# Altium schematic sheet Sheet2.SchDoc(Sheet2)
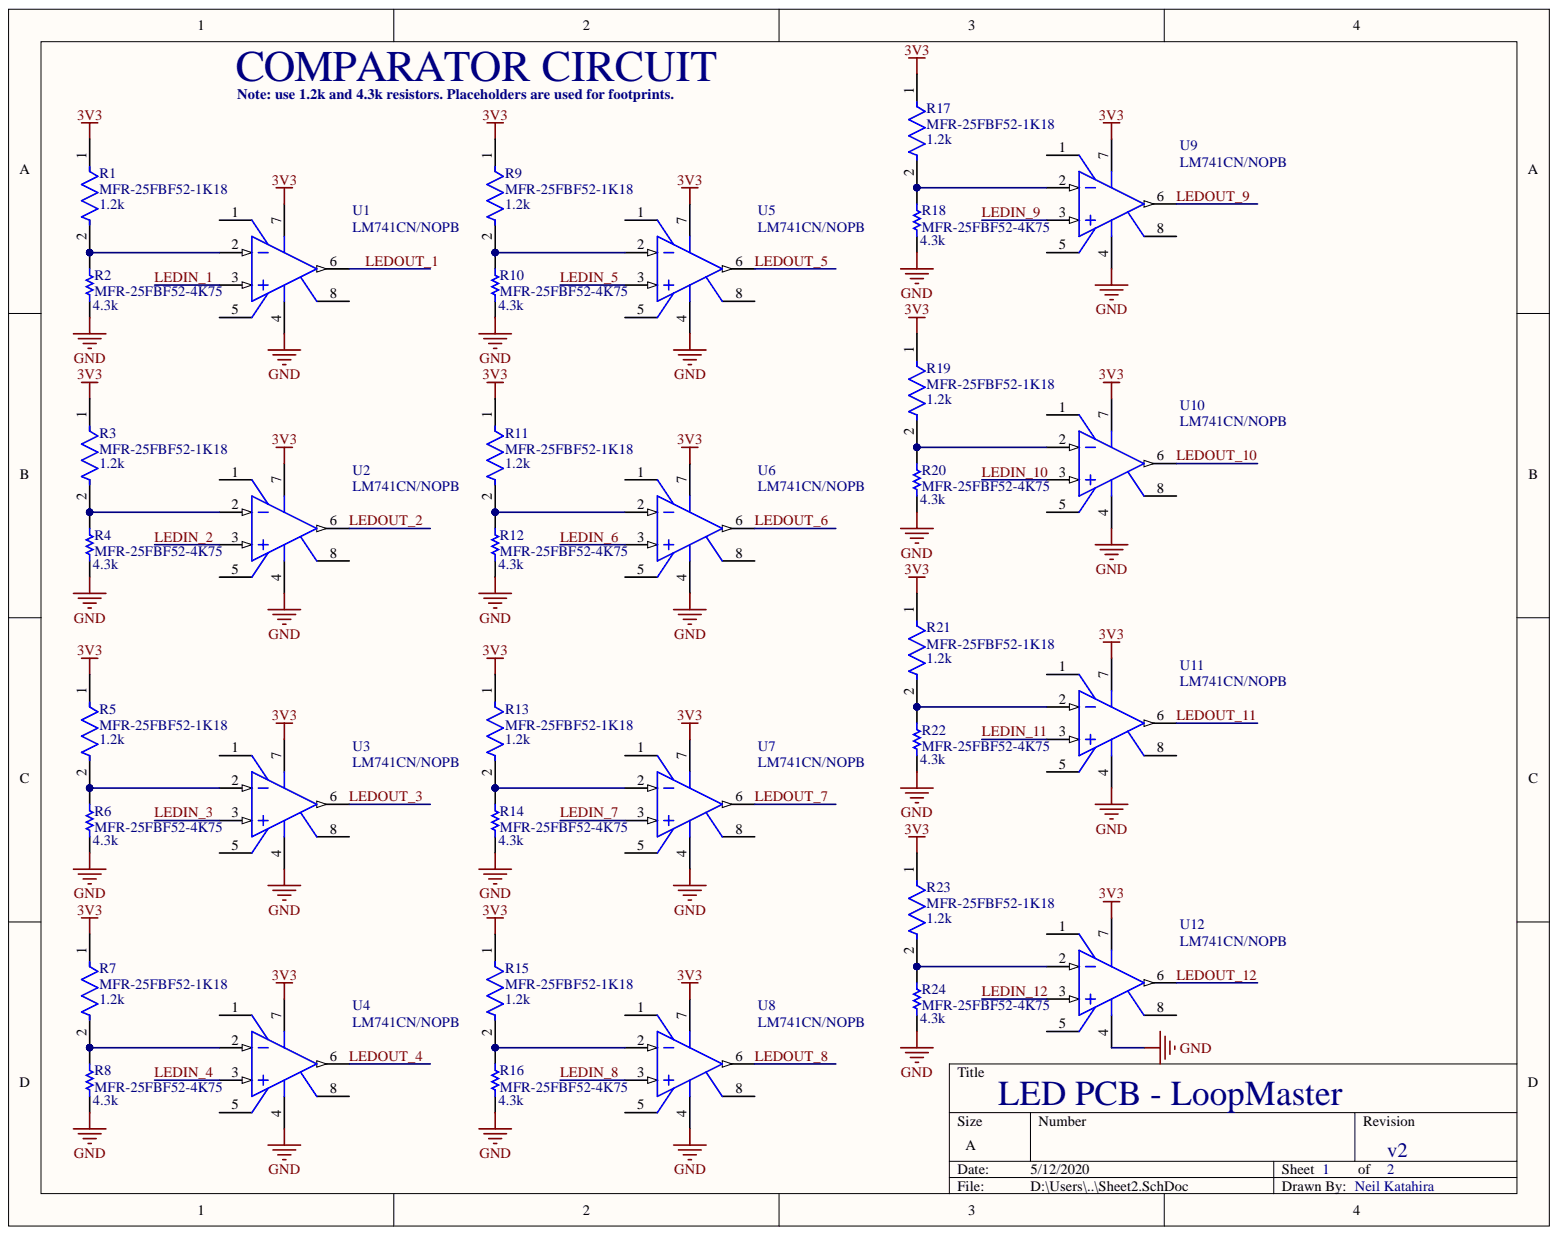
[diagram p0: Sheet2.SchDoc]

## Parts
R1  MFR-25FBF52-1K18  [FP-MFR-25-MFG]
R2  MFR-25FBF52-4K75  [RESA60-630X240]
R3  MFR-25FBF52-1K18  [FP-MFR-25-MFG]
R4  MFR-25FBF52-4K75  [RESA60-630X240]
R5  MFR-25FBF52-1K18  [FP-MFR-25-MFG]
R6  MFR-25FBF52-4K75  [RESA60-630X240]
R7  MFR-25FBF52-1K18  [FP-MFR-25-MFG]
R8  MFR-25FBF52-4K75  [RESA60-630X240]
R9  MFR-25FBF52-1K18  [FP-MFR-25-MFG]
R10  MFR-25FBF52-4K75  [RESA60-630X240]
R11  MFR-25FBF52-1K18  [FP-MFR-25-MFG]
R12  MFR-25FBF52-4K75  [RESA60-630X240]
R13  MFR-25FBF52-1K18  [FP-MFR-25-MFG]
R14  MFR-25FBF52-4K75  [RESA60-630X240]
R15  MFR-25FBF52-1K18  [FP-MFR-25-MFG]
R16  MFR-25FBF52-4K75  [RESA60-630X240]
R17  MFR-25FBF52-1K18  [FP-MFR-25-MFG]
R18  MFR-25FBF52-4K75  [RESA60-630X240]
R19  MFR-25FBF52-1K18  [FP-MFR-25-MFG]
R20  MFR-25FBF52-4K75  [RESA60-630X240]
R21  MFR-25FBF52-1K18  [FP-MFR-25-MFG]
R22  MFR-25FBF52-4K75  [RESA60-630X240]
R23  MFR-25FBF52-1K18  [FP-MFR-25-MFG]
R24  MFR-25FBF52-4K75  [RESA60-630X240]
U1  LM741CN/NOPB  [P0008A]
U2  LM741CN/NOPB  [P0008A]
U3  LM741CN/NOPB  [P0008A]
U4  LM741CN/NOPB  [P0008A]
U5  LM741CN/NOPB  [P0008A]
U6  LM741CN/NOPB  [P0008A]
U7  LM741CN/NOPB  [P0008A]
U8  LM741CN/NOPB  [P0008A]
U9  LM741CN/NOPB  [P0008A]
U10  LM741CN/NOPB  [P0008A]
U11  LM741CN/NOPB  [P0008A]
U12  LM741CN/NOPB  [P0008A]

## Nets
3V3: R1.1 R3.1 R5.1 R7.1 R9.1 R11.1 R13.1 R15.1 R17.1 R19.1 R21.1 R23.1 U1.7 U2.7 U3.7 U4.7 U5.7 U6.7 U7.7 U8.7 U9.7 U10.7 U11.7 U12.7
GND: R2.1 R4.1 R6.1 R8.1 R10.1 R12.1 R14.1 R16.1 R18.1 R20.1 R22.1 R24.1 U1.4 U2.4 U3.4 U4.4 U5.4 U6.4 U7.4 U8.4 U9.4 U10.4 U11.4 U12.4
LEDIN_1: U1.3
LEDIN_2: U2.3
LEDIN_3: U3.3
LEDIN_4: U4.3
LEDIN_5: U5.3
LEDIN_6: U6.3
LEDIN_7: U7.3
LEDIN_8: U8.3
LEDIN_9: U9.3
LEDIN_10: U10.3
LEDIN_11: U11.3
LEDIN_12: U12.3
LEDOUT_1: U1.6
LEDOUT_2: U2.6
LEDOUT_3: U3.6
LEDOUT_4: U4.6
LEDOUT_5: U5.6
LEDOUT_6: U6.6
LEDOUT_7: U7.6
LEDOUT_8: U8.6
LEDOUT_9: U9.6
LEDOUT_10: U10.6
LEDOUT_11: U11.6
LEDOUT_12: U12.6
NetR1_2: R1.2 R2.2 U1.2
NetR3_2: R3.2 R4.2 U2.2
NetR5_2: R5.2 R6.2 U3.2
NetR7_2: R7.2 R8.2 U4.2
NetR9_2: R9.2 R10.2 U5.2
NetR11_2: R11.2 R12.2 U6.2
NetR13_2: R13.2 R14.2 U7.2
NetR15_2: R15.2 R16.2 U8.2
NetR17_2: R17.2 R18.2 U9.2
NetR19_2: R19.2 R20.2 U10.2
NetR21_2: R21.2 R22.2 U11.2
NetR23_2: R23.2 R24.2 U12.2

Not connected: U1 1 5 8; U2 1 5 8; U3 1 5 8; U4 1 5 8; U5 1 5 8; U6 1 5 8; U7 1 5 8; U8 1 5 8; U9 1 5 8; U10 1 5 8; U11 1 5 8; U12 1 5 8

## Library data of the parts
R1 R3 R5 R7 R9 R11 R13 R15 R17 R19 R21 R23 = CMP-03413-000001-1: RES 1.18K OHM 1/4W 1% AXIAL; Manufacturer: Yageo; Voltage Rating: 250V; Value: 1.18kΩ; Temperature Coefficient: 100ppm/°C; Power Rating: 0.25W; Tolerance: 1%; Temperature Range Low: -55°C; Temperature Range High: +155°C; Temperature: -55°C to +155°C; Series: MFR; RoHS: TRUE; Resistance: 1.18kΩ; Package: MFR-25; Octopart: https://octopart.com/mfr-25fbf52-1k18-yageo-56251681; Mouser Description: Metal Film Resistors 1.18K OHM 1/4W 1%; Manufacturer Part Number: MFR-25FBF52-1K18; Library Name: Altium Content Vault; Lead Free: Yes; Ipc Land Pattern Name: RESAD1000W75L680D260; Height: 2.6mm; Digikey Description: RES 1.18K OHM 1/4W 1% AXIAL; Device Class L3: Through-Hole Resistors; Device Class L2: Resistors; Device Class L1: Passive Components; Composition: Metal Film; ComponentLink2URL: https://www.yageo.com/upload/media/product/products/datasheet/lr/Yageo_LR_MFR_1.pdf; ComponentLink2Description: Datasheet; Component Kind: Standard; Category: Res; Automotive: No
R2 R4 R6 R8 R10 R12 R14 R16 R18 R20 R22 R24 = CMP-1659-00066-1: Axial Resistor, 4.75 KOhm, +/- 1%, 0.25 W, -55 to 155 degC, 2-Pin THD, RoHS, Bulk; Voltage Rating (DC): 25 V; Type (Capacitors): X7R; Tolerance: 10%; Case\Package: 1210; Capacitance: 22 uF; Width: 2.5 mm; Voltage Rating: 25 V; RoHSCompliant: true; REACH SVHC: No SVHC; Radiation Hardening: No; Pins: 2; Packaging: Tape and Reel; PackageReference: MFR-25; Package Quantity: 1000; PackageDescription: 2-Pin Axial Lead, Dia 2.4 mm, Body Length 6.3 mm; Mount: Surface Mount; Min Operating Temperature: -55 degC; Max Operating Temperature: 125 degC; Library Name: Altium Content Vault; Length: 3.2 mm; Height: 2.5 mm; DatasheetVersion: Apr-13; ComponentLink2URL: http://www.yageo.com/documents/recent/Yageo%20LR_MFR_2013.pdf; ComponentLink2Description: Datasheet; ComponentLink1URL: http://www.yageo.com/; ComponentLink1Description: Manufacturer URL; Component Kind: Standard; Case Code (Metric): 3225; Case Code (Imperial): 1210
U1-U12 = CMP-0055-00162-3: Operational Amplifier, 8-pin MDIP, Pb-Free; Manufacturer: Texas Instruments; PowerWise: N; PackageTopMarking: NSUZXYTTE#*LM*741CN; CompType: Amplifiers; RoHS: Y; PinCount: 8; Package Reference: P0008A; PackageDescription: MDIP, .365 x .250 x .130in, 8 Lead, .100in Pitch; Library Name: Altium Content Vault; ComponentLink1URL: http://www.ti.com/general/docs/lit/getliterature.tsp?genericPartNumber=LM741&fileType=pdf; ComponentLink1Description: Datasheet URL; Component Kind: Standard; Code_JEDEC: MS-001-BA
